# Altium schematic sheet Linkwitz_Transform.SchDoc
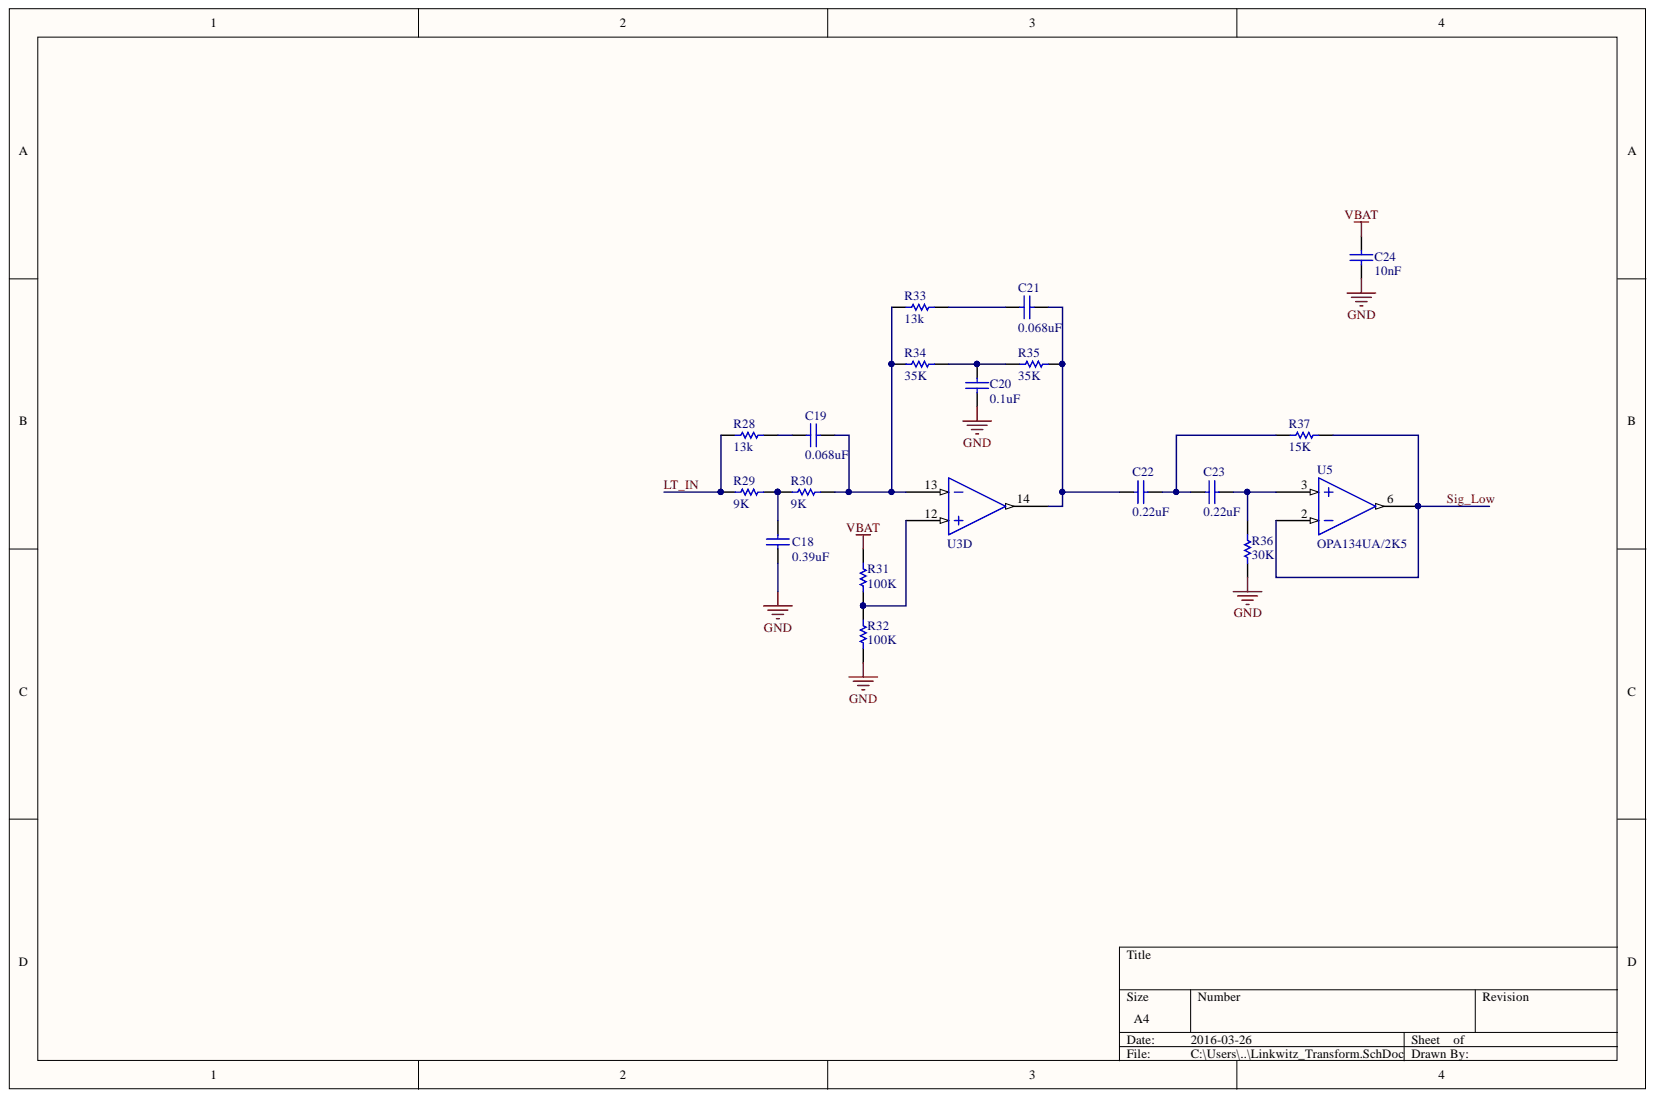
[diagram p0: Linkwitz_Transform.SchDoc]

## Parts
C18  0.39uF
C19  0.068uF  [CAP1913]
C20  0.1uF  [CAP1913]
C21  0.068uF  [CAP1913]
C22  0.22uF  [CAP FILM 1UF RADIAL 5MM]
C23  0.22uF  [CAP FILM 1UF RADIAL 5MM]
C24  10nF  [CAPC1608X09N]
R28  13k  [0603]
R29  9K  [0603]
R30  9K  [0603]
R31  100K  [0603]
R32  100K  [0603]
R33  13k  [0603]
R34  35K  [0603]
R35  35K  [0603]
R36  30K  [0603]
R37  15K  [0603]
U3  OPA4134UA  [SOIC127P600X175-14N]  (value not drawn)  (part D)
U5  OPA134UA/2K5  [SOIC127P600X175-8N]

## Nets
GND: C18.1 C20.2 C24.1 R32.1 R36.1 U3.11 U5.4
VBAT: C24.2 R31.2 U3.4 U5.7
LT_IN: R28.1 R29.1
SIG_LOW: R37.2 U5.2 U5.6
NetC18_2: C18.2 R29.2 R30.1
NetC19_1: C19.1 R28.2
NetC19_2: C19.2 R30.2 R33.1 R34.1 U3.13
NetC20_1: C20.1 R34.2 R35.1
NetC21_1: C21.1 R33.2
NetC21_2: C21.2 C22.1 R35.2 U3.14
NetC22_2: C22.2 C23.1 R37.1
NetC23_2: C23.2 R36.2 U5.3
NetR31_1: R31.1 R32.2 U3.12

## Library data of the parts
C18 = CAP 0.39uF Radial 5mm: Manufacturer: EPCOS (TDK); Manufacturer P/N: B32529C105J; Voltage: 63V; Component Kind: Standard; Digikey Part Number: 495-4846-1-ND; Value: 0.39uF; Library Name: Speaker Library.SchLib
C19 C21 = Capacitor 0.068uF 1913: Component Kind: Standard; Description: Capacitor 0.068uF 1913; Digikey Part Number: PCF1550CT-ND; Value: 0.068uF; Library Name: Speaker Library.SchLib
C20 = Capacitor 0.1uF 1913: Component Kind: Standard; Description: Capacitor 0.1uF 1913; Digikey Part Number: PCF1552CT-ND; Value: 0.1uF; Library Name: Speaker Library.SchLib
C22 C23 = CAP 0.22uF Radial 5mm: Manufacturer: EPCOS (TDK); Voltage: 100V; Component Kind: Standard; Digikey Part Number: 495-4985-1-ND; Value: 0.22uF; Library Name: Speaker Library.SchLib
C24 = Capacitor 10nF 0603: Capacitor 2.2nF 0805; Component Kind: Standard; Digikey Part Number: 311-1085-1-ND; Value: 10nF; Library Name: Speaker Library.SchLib
R28 R33 = RES 13K 0603: Tolerance: 1%; Component Kind: Standard; Type: RES; Value: 13k; Library Name: Speaker Library.SchLib
R29 R30 = RES 9K 0603: Tolerance: 1%; Component Kind: Standard; Type: RES; Value: 9K; Library Name: Speaker Library.SchLib
R31 R32 = RES 100K 0603: Power: 0.1W; Tolerance: 1%; Component Kind: Standard; Value: 100K; Library Name: Speaker Library.SchLib
R34 R35 = RES 35K 0603: Tolerance: 1%; Component Kind: Standard; Type: RES; Value: 35K; Library Name: Speaker Library.SchLib
R36 = RES 30K 0603: Tolerance: 1%; Component Kind: Standard; Type: RES; Value: 30K; Library Name: Speaker Library.SchLib
R37 = RES 15K 0306: Tolerance: 1%; Component Kind: Standard; Type: RES; Value: 15K; Library Name: Speaker Library.SchLib
U3 = OPA4134UA: Quad OPAx134 Operational Amplifier; Component Kind: Standard; Library Name: Speaker Library.SchLib
U5 = OPA134UA/2K5: Component Kind: Standard; Digikey Part Number: 296-26241-1-ND; Library Name: Speaker Library.SchLib
